# Altium schematic sheet MCU.SchDoc
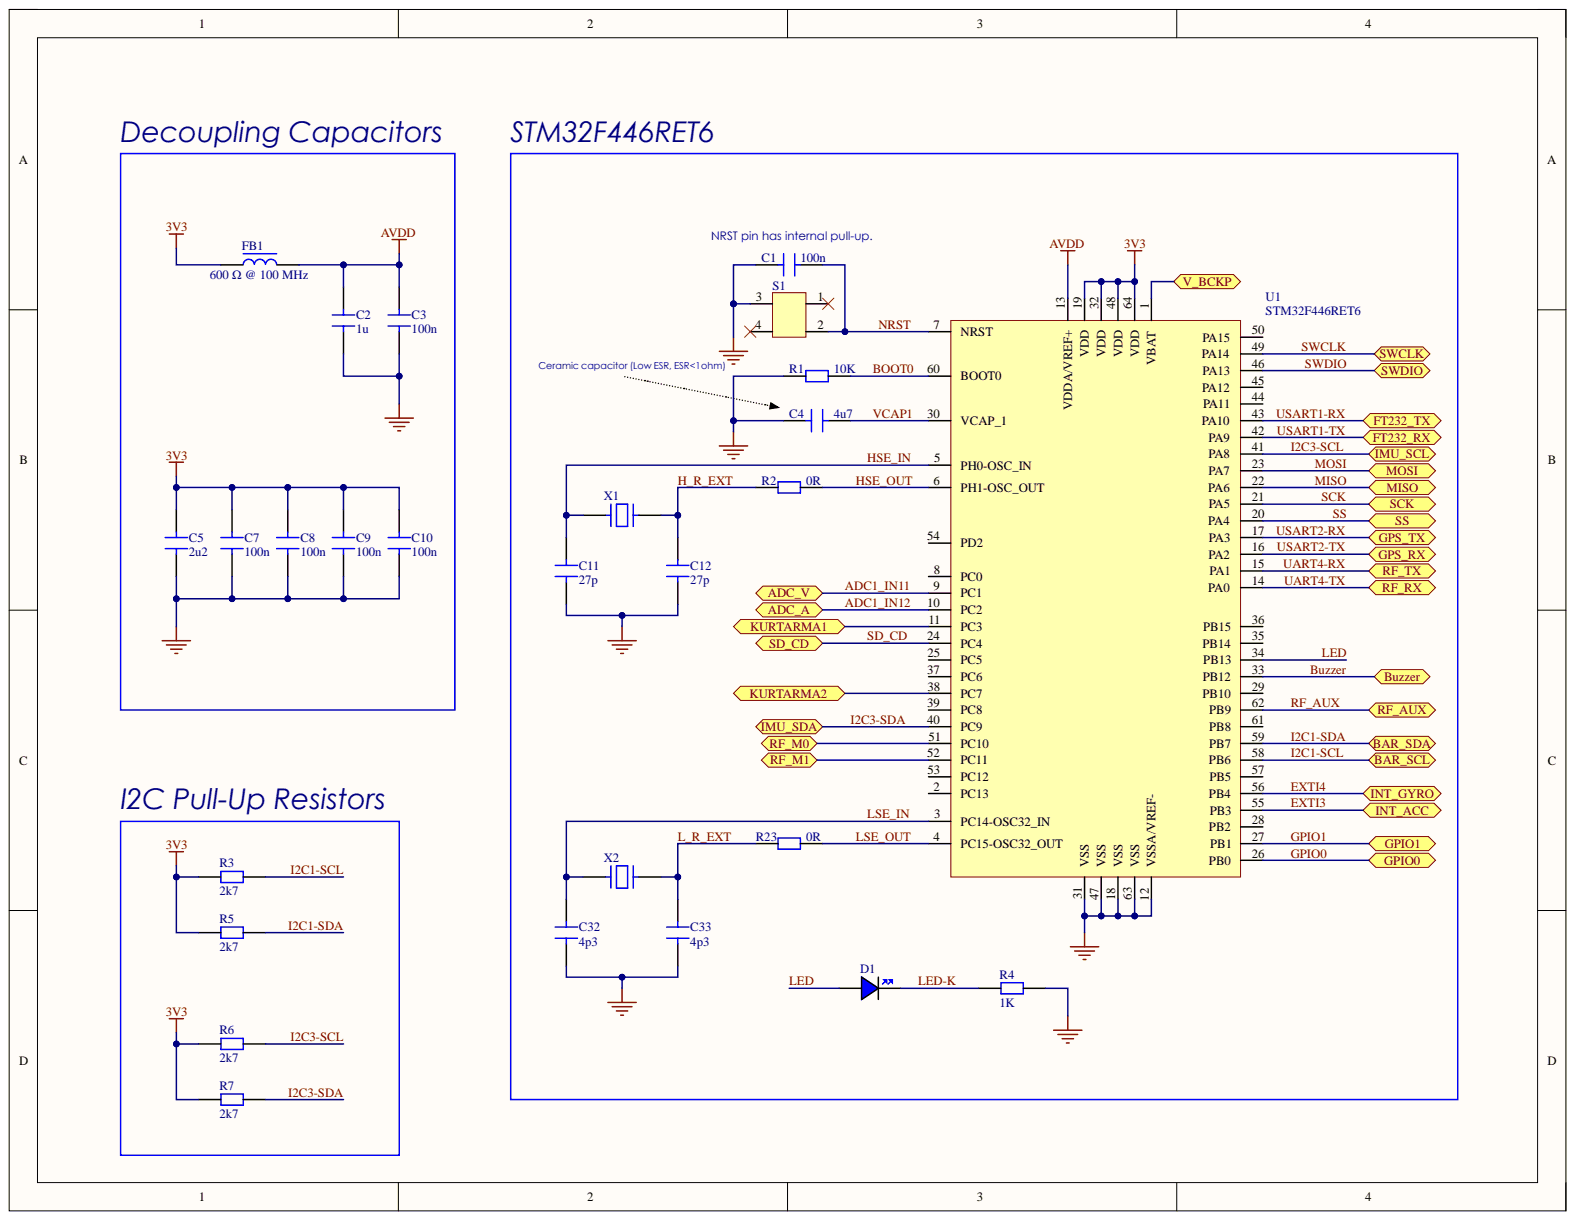
[diagram p0: MCU.SchDoc]

## Parts
C1  100n  [CAP 0603]
C2  1u  [CAP 0805]
C3  100n  [CAP 0603]
C4  4u7  [CAP 0805]
C5  2u2  [CAP 0805]
C7  100n  [CAP 0603]
C8  100n  [CAP 0603]
C9  100n  [CAP 0603]
C10  100n  [CAP 0603]
C11  27p  [CAP 0603]
C12  27p  [CAP 0603]
C32  4p3  [CAP 0603]
C33  4p3  [CAP 0603]
D1  LED 0805 BLUE  [LED 0805 BLUE]  (value not drawn)
FB1  600 Ω @ 100 MHz  [FB 0805]
R1  10K  [RES 0603]
R2  0R  [RES 0603]
R3  2k7  [RES 0603]
R4  1K  [RES 0603]
R5  2k7  [RES 0603]
R6  2k7  [RES 0603]
R7  2k7  [RES 0603]
R23  0R  [RES 0603]
S1  FSM4JSMATR  [FSM4JSMATR]  (value not drawn)
U1  STM32F446RET6  [STM32F446RET6]
X1  ABM3-8.000MHZ-D2Y-T  [ABM3-8.000MHZ-D2Y-T]  (value not drawn)
X2  ABS25-32.768KHZ-6-T  [ABS25-32.768KHZ-6-T]  (value not drawn)

## Ports
ADC_A, ADC_V, BAR_SCL, BAR_SDA, Buzzer, FT232_RX, FT232_TX, GPIO0, GPIO1, GPS_RX, GPS_TX, IMU_SCL, IMU_SDA, INT_ACC, INT_GYRO, KURTARMA1, KURTARMA2, MISO, MOSI, RF_AUX, RF_M0, RF_M1, RF_RX, RF_TX, SCK, SD_CD, SS, SWCLK, SWDIO, V_BCKP

## Nets
3V3: C5.2 C7.2 C8.2 C9.2 C10.2 FB1.1 R3.2 R5.2 R6.2 R7.2 U1.19(VDD) U1.32(VDD) U1.48(VDD) U1.64(VDD)
AVDD: C2.2 C3.2 FB1.2 U1.13(VDDA/VREF+)
GND: C1.1 C2.1 C3.1 C4.1 C5.1 C7.1 C8.1 C9.1 C10.1 C11.1 C12.1 C32.1 C33.1 R1.1 R4.2 S1.3 U1.12(VSSA/VREF-) U1.18(VSS) U1.31(VSS) U1.47(VSS) U1.63(VSS)
ADC1_IN11: U1.9(PC1) ; port ADC_V
ADC1_IN12: U1.10(PC2) ; port ADC_A
BOOT0: R1.2 U1.60(BOOT0)
Buzzer: U1.33(PB12) ; port Buzzer
EXTI3: U1.55(PB3) ; port INT_ACC
EXTI4: U1.56(PB4) ; port INT_GYRO
GPIO0: U1.26(PB0) ; port GPIO0
GPIO1: U1.27(PB1) ; port GPIO1
H_R_EXT: C12.2 R2.1 X1.2
HSE_IN: C11.2 U1.5(PH0-OSC_IN) X1.1
HSE_OUT: R2.2 U1.6(PH1-OSC_OUT)
I2C1-SCL: R3.1 U1.58(PB6) ; port BAR_SCL
I2C1-SDA: R5.1 U1.59(PB7) ; port BAR_SDA
I2C3-SCL: R6.1 U1.41(PA8) ; port IMU_SCL
I2C3-SDA: R7.1 U1.40(PC9) ; port IMU_SDA
KURTARMA1: U1.11(PC3) ; port KURTARMA1
KURTARMA2: U1.38(PC7) ; port KURTARMA2
L_R_EXT: C33.2 R23.1 X2.4
LED: D1.A U1.34(PB13)
LED-K: D1.K R4.1
LSE_IN: C32.2 U1.3(PC14-OSC32_IN) X2.1
LSE_OUT: R23.2 U1.4(PC15-OSC32_OUT)
MISO: U1.22(PA6) ; port MISO
MOSI: U1.23(PA7) ; port MOSI
NRST: C1.2 S1.2 U1.7(NRST)
RF_AUX: U1.62(PB9) ; port RF_AUX
RF_M0: U1.51(PC10) ; port RF_M0
RF_M1: U1.52(PC11) ; port RF_M1
SCK: U1.21(PA5) ; port SCK
SD_CD: U1.24(PC4) ; port SD_CD
SS: U1.20(PA4) ; port SS
SWCLK: U1.49(PA14) ; port SWCLK
SWDIO: U1.46(PA13) ; port SWDIO
UART4-RX: U1.15(PA1) ; port RF_TX
UART4-TX: U1.14(PA0) ; port RF_RX
USART1-RX: U1.43(PA10) ; port FT232_TX
USART1-TX: U1.42(PA9) ; port FT232_RX
USART2-RX: U1.17(PA3) ; port GPS_TX
USART2-TX: U1.16(PA2) ; port GPS_RX
V_BCKP: U1.1(VBAT) ; port V_BCKP
VCAP1: C4.2 U1.30(VCAP_1)

Not connected: S1 1 4; U1 2(PC13) 8(PC0) 25(PC5) 28(PB2) 29(PB10) 35(PB14) 36(PB15) 37(PC6) 39(PC8) 44(PA11) 45(PA12) 50(PA15) 53(PC12) 54(PD2) 57(PB5) 61(PB8)

## Library data of the parts
C1 C3 C7-C12 C32 C33 = CAP 0603 50V: Capacitor SMD 0603 50V; Component Kind: Standard; Library Name: Schlib.SchLib
C2 = CAP 0805 50V: Capacitor SMD 0805 50V; Component Kind: Standard; Library Name: Schlib.SchLib
C4 C5 = CAP 0805 25V: Capacitor SMD 0805 25V; Component Kind: Standard; Library Name: Schlib.SchLib
D1 = LED 0805 BLUE: LED 0805 BLUE SMD; Component Kind: Standard; Library Name: Schlib.SchLib
FB1 = FB 0805: Ferrite Bead SMD 0805; Component Kind: Standard; Library Name: Schlib.SchLib
R1-R7 R23 = RES 0603: Resistor SMD 0603; Component Kind: Standard; Library Name: Schlib.SchLib
S1 = FSM4JSMATR: Switch Tactile OFF (ON) SPST Round Button Gull Wing 0.05A 24VDC 1.57N SMD T/R; Manufacturer: TE Connectivity Alcoswitch; Category: Pushbutton Switches; Component Kind: Standard; ComponentLink1Description: https://datasheet.ciiva.com/16320/eng-cs-1308111-1-switches-core-program-catalog-0308-16320065.pdf?src-supplier=Future+Electronics; ComponentLink1URL: https://datasheet.ciiva.com/16320/eng-cs-1308111-1-switches-core-program-catalog-0308-16320065.pdf?src-supplier=Future+Electronics; ComponentLink2Description: https://datasheet.ciiva.com/1530/1683375-1530944.pdf?src-supplier=Element14; ComponentLink2URL: https://datasheet.ciiva.com/1530/1683375-1530944.pdf?src-supplier=Element14; ComponentLink3Description: https://datasheet.ciiva.com/23765/1486934-23765878.pdf?src-supplier=Newark; ComponentLink3URL: https://datasheet.ciiva.com/23765/1486934-23765878.pdf?src-supplier=Newark; ComponentLink4Description: https://datasheet.ciiva.com/7638/921681-7638037.pdf?src-supplier=Element14; ComponentLink4URL: https://datasheet.ciiva.com/7638/921681-7638037.pdf?src-supplier=Element14; Library Name: Schlib.SchLib; Manufacturer Part Number: FSM4JSMATR; Pricing 1: 1=0,36, 10=0,351, 25=0,33, 100=0,2866, 250=0,26, 500=0,24188 (USD); RoHS: Yes; Stock 1: 84996; Supplier 1: Digi-Key
U1 = STM32F446RET6: ARM® Cortex®-M4 STM32 F4 Microcontroller IC 32-Bit 180MHz 512KB (512K x 8) FLASH 64-LQFP (10x10); Manufacturer: STMicroelectronics; Category: Microcontrollers; Component Kind: Standard; ComponentLink1Description: http://www.mouser.com/ds/2/389/stm32f446mc-956216.pdf?src-supplier=Mouser; ComponentLink1URL: http://www.mouser.com/ds/2/389/stm32f446mc-956216.pdf?src-supplier=Mouser; ComponentLink2Description: http://www.farnell.com/datasheets/2309063.pdf?src-supplier=Farnell; ComponentLink2URL: http://www.farnell.com/datasheets/2309063.pdf?src-supplier=Farnell; ComponentLink3Description: https://datasheet.octopart.com/STM32F446RET6-STMicroelectronics-datasheet-58295083.pdf?src-supplier=Future+Electronics; ComponentLink3URL: https://datasheet.octopart.com/STM32F446RET6-STMicroelectronics-datasheet-58295083.pdf?src-supplier=Future+Electronics; ComponentLink4Description: http://www.st.com/content/ccc/resource/technical/document/datasheet/65/cb/75/50/53/d6/48/24/DM00141306.pdf/files/DM00141306.pdf/jcr:content/translations/en.DM00141306.pdf?src-supplier=Digi-Key; ComponentLink4URL: http://www.st.com/content/ccc/resource/technical/document/datasheet/65/cb/75/50/53/d6/48/24/DM00141306.pdf/files/DM00141306.pdf/jcr:content/translations/en.DM00141306.pdf?src-supplier=Digi-Key; ComponentLink5Description: http://www.farnell.com/datasheets/2309063.pdf?src-supplier=Element14; ComponentLink5URL: http://www.farnell.com/datasheets/2309063.pdf?src-supplier=Element14; ComponentLink6Description: https://datasheet.octopart.com/STM32F446RET6-STMicroelectronics-datasheet-41797127.pdf?src-supplier=Element14; ComponentLink6URL: https://datasheet.octopart.com/STM32F446RET6-STMicroelectronics-datasheet-41797127.pdf?src-supplier=Element14; ComponentLink7Description: http://www.farnell.com/datasheets/2309063.pdf?src-supplier=Newark; ComponentLink7URL: http://www.farnell.com/datasheets/2309063.pdf?src-supplier=Newark; ComponentLink8Description: https://datasheet.octopart.com/STM32F446RET6-STMicroelectronics-datasheet-83961502.pdf?src-supplier=SHENGYU+ELECTRONICS; ComponentLink8URL: https://datasheet.octopart.com/STM32F446RET6-STMicroelectronics-datasheet-83961502.pdf?src-supplier=SHENGYU+ELECTRONICS; Library Name: Schlib.SchLib; Manufacturer Part Number: STM32F446RET6; Pricing 1: 1=12,45, 10=11,25, 50=10,54, 100=9,5, 1000=6,72, 10000=6,72 (USD); RoHS: Yes; Stock 1: 2954; Supplier 1: Mouser
X1 = ABM3-8.000MHZ-D2Y-T: Crystal 8MHz ±20ppm (Tol) ±30ppm (Stability) 18pF FUND 140Ohm 2-Pin Mini-CSMD T/R; Manufacturer: Abracon; Category: Crystals; Component Kind: Standard; ComponentLink1Description: https://datasheet.ciiva.com/862/abm3-862465.pdf?src-supplier=Digikey; ComponentLink1URL: https://datasheet.ciiva.com/862/abm3-862465.pdf?src-supplier=Digikey; Library Name: Schlib.SchLib; Manufacturer Part Number: ABM3-8.000MHZ-D2Y-T; Pricing 1: 1000=0,325, 2000=0,275, 3000=0,315, 4000=0,27, 5000=0,31, 10000=0,305, 20000=0,295 (USD); Reach SVHC Compliant: 4187SVHC; RoHS: Yes; Stock 1: 237000; Supplier 1: Future Electronics
X2 = ABS25-32.768KHZ-6-T: Crystal, Plastic Molded 32.768Khz, 6Pf, 20Ppm-40+85C, 8 X 3.8 X 2.5 Rohs Compliant: Yes; Manufacturer: Abracon; Category: Crystals; Component Kind: Standard; ComponentLink1Description: https://datasheet.ciiva.com/854/abs25-854528.pdf?src-supplier=Digi-Key; ComponentLink1URL: https://datasheet.ciiva.com/854/abs25-854528.pdf?src-supplier=Digi-Key; ComponentLink2Description: https://datasheet.ciiva.com/26909/getdatasheetpartid-722397-26909970.pdf?src-supplier=Verical; ComponentLink2URL: https://datasheet.ciiva.com/26909/getdatasheetpartid-722397-26909970.pdf?src-supplier=Verical; ComponentLink3Description: https://datasheet.ciiva.com/854/abs25-854527.pdf?src-supplier=Digi-Key; ComponentLink3URL: https://datasheet.ciiva.com/854/abs25-854527.pdf?src-supplier=Digi-Key; ComponentLink4Description: https://datasheet.ciiva.com/854/abs25-854529.pdf?src-supplier=Digi-Key; ComponentLink4URL: https://datasheet.ciiva.com/854/abs25-854529.pdf?src-supplier=Digi-Key; ComponentLink5Description: https://datasheet.ciiva.com/5788/abs25-5788102.pdf?src-supplier=Farnell; ComponentLink5URL: https://datasheet.ciiva.com/5788/abs25-5788102.pdf?src-supplier=Farnell; ComponentLink6Description: https://datasheet.ciiva.com/1357/1856019-1357324.pdf?src-supplier=Element14; ComponentLink6URL: https://datasheet.ciiva.com/1357/1856019-1357324.pdf?src-supplier=Element14; ComponentLink7Description: https://datasheet.ciiva.com/7998/1856019-7998662.pdf?src-supplier=Element14; ComponentLink7URL: https://datasheet.ciiva.com/7998/1856019-7998662.pdf?src-supplier=Element14; Library Name: Schlib.SchLib; Manufacturer Part Number: ABS25-32.768KHZ-6-T; Pricing 1: 3000=0,375, 6000=0,365, 9000=0,365, 12000=0,36, 15000=0,35 (USD); RoHS: Yes; Stock 1: 399000; Supplier 1: Future Electronics
